# Altium schematic sheet I2C EEPROM.SchDoc("I2C EEPROM")
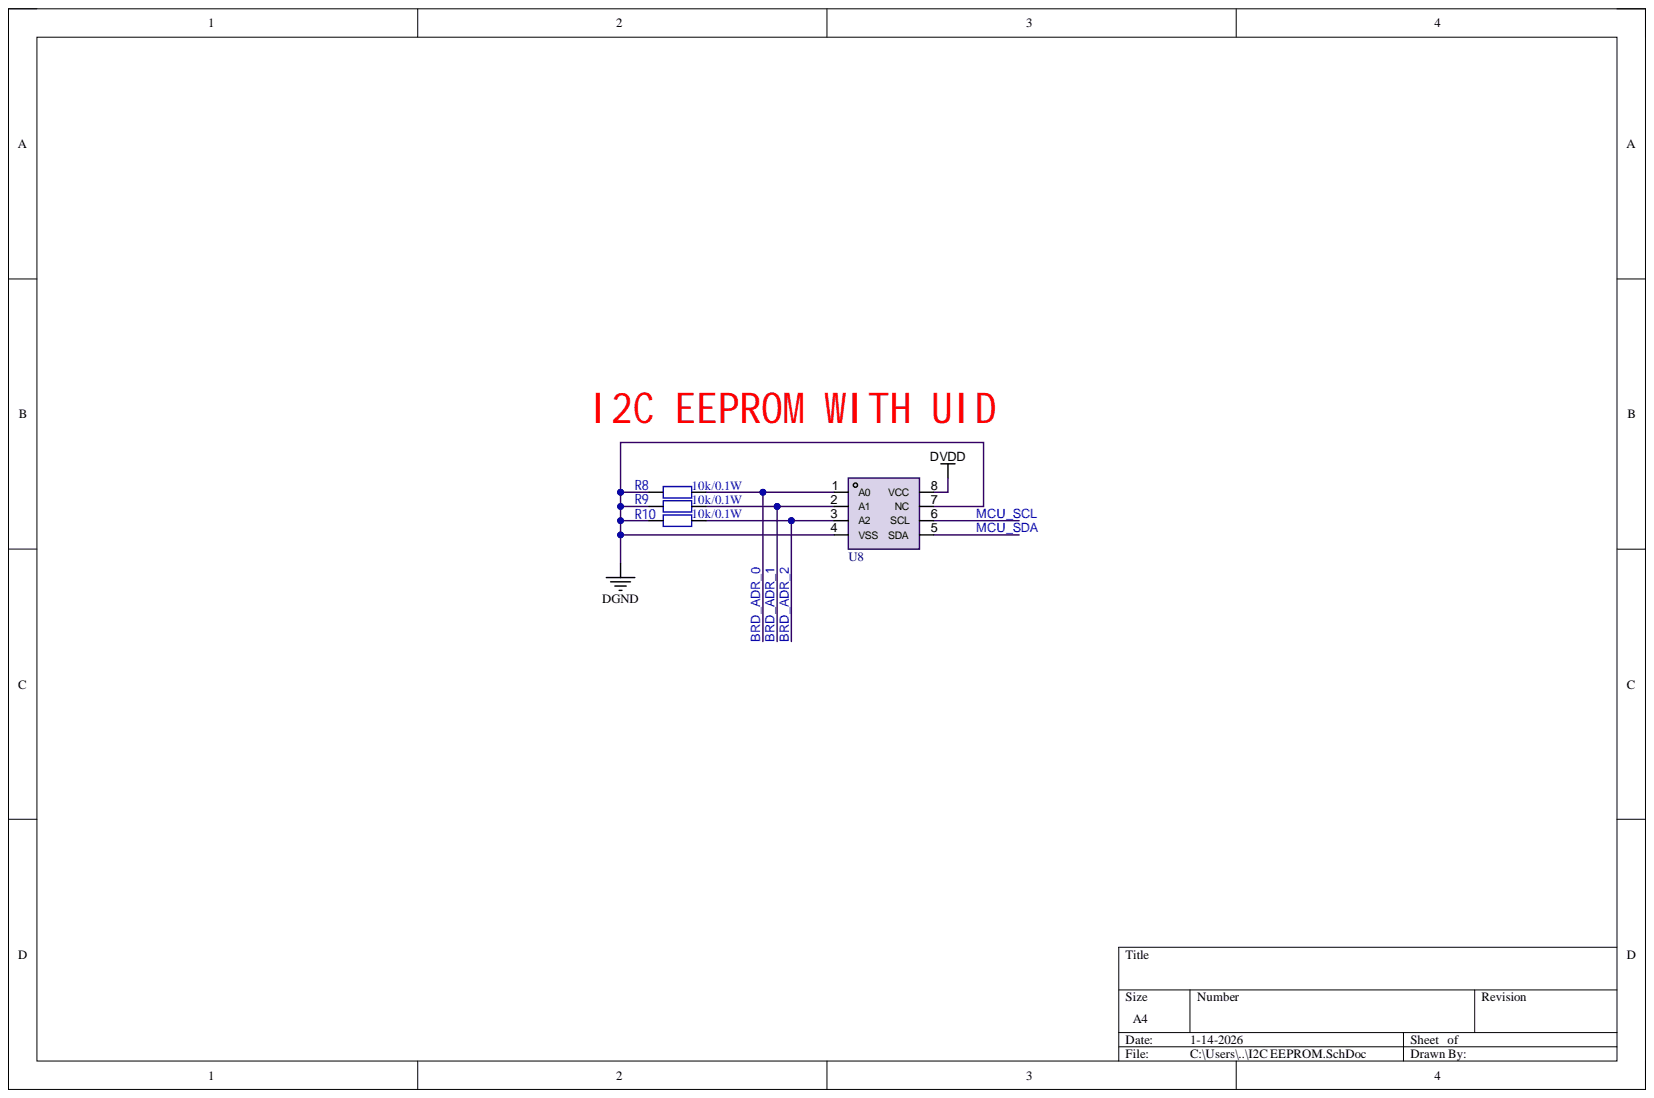
[diagram p0: I2C EEPROM.SchDoc]

## Parts
R8  value 10k/0.1W  [R0603]  (hidden comment: 10K)
R9  value 10k/0.1W  [R0603]  (hidden comment: 10K)
R10  value 10k/0.1W  [R0603]  (hidden comment: 10K)
U8  24AA256UID-I/SN  [SOIC-8]  (value not drawn)

## Nets
DGND: R8.1 R9.1 R10.1 U8.4(VSS) U8.7(NC)
DVDD: U8.8(VCC)
BRD_ADR_0: R8.2 U8.1(A0)
BRD_ADR_1: R9.2 U8.2(A1)
BRD_ADR_2: R10.2 U8.3(A2)
MCU_SCL: U8.6(SCL)
MCU_SDA: U8.5(SDA)

## Library data of the parts
R8-R10 = RC0603JR-0710KL: RES 10K OHM 5% 1/10W 0603; Add into BOM: yes; Device: Res_0603; Component Kind: Standard; ComponentLink1Description: Product link; ComponentLink1URL: https://www.digikey.in/en/products/detail/yageo/RC0603JR-0710KL/726700; Convert to PCB: yes; Library Name: US00001.SCHLIB; Supplier Footprint: 0603; Symbol: Res
U8 = 24AA256UID-I/SN: IC EEPROM 256KBIT I2C 8SOIC; Component Kind: Standard; ComponentLink1Description: Product link; ComponentLink1URL: https://www.digikey.in/en/products/detail/microchip-technology/24AA256UID-I-SN/4439784; ComponentLink2Description: Datasheet link; ComponentLink2URL: https://ww1.microchip.com/downloads/en/DeviceDoc/24AA256UID-256K-I2C-Serial-EEPROM-with-EUI48-EUI64-20005215D.pdf; Library Name: US00001.SCHLIB
